# Altium schematic sheet mmb-2016-003_io.schdoc(mmb-2016-003_io)
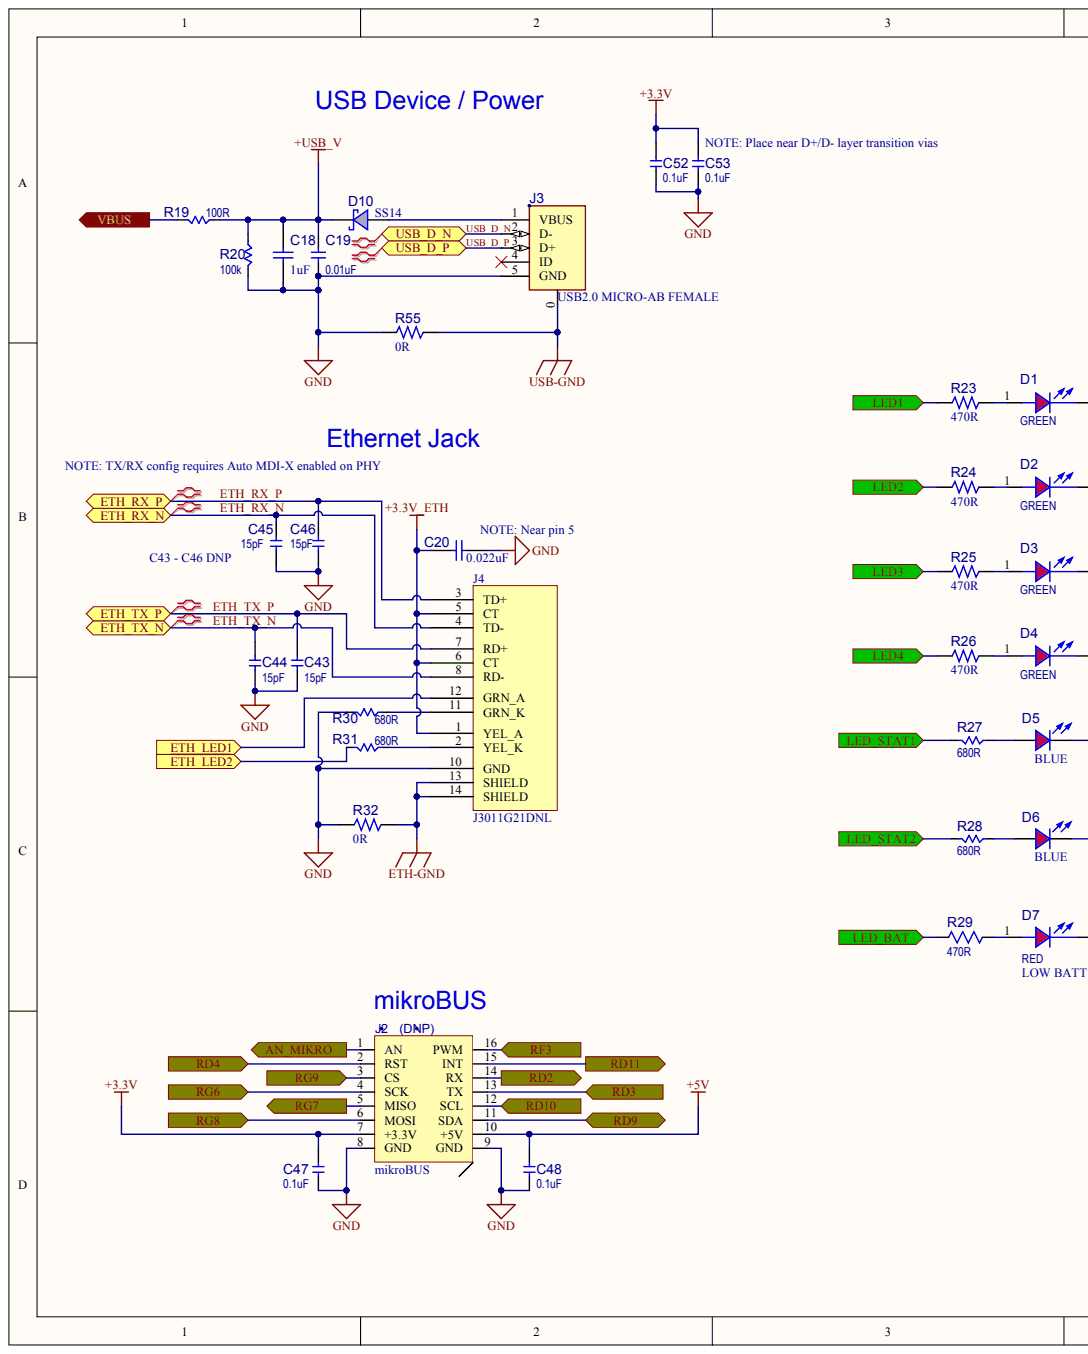
[diagram p0: mmb-2016-003_io.schdoc, left]
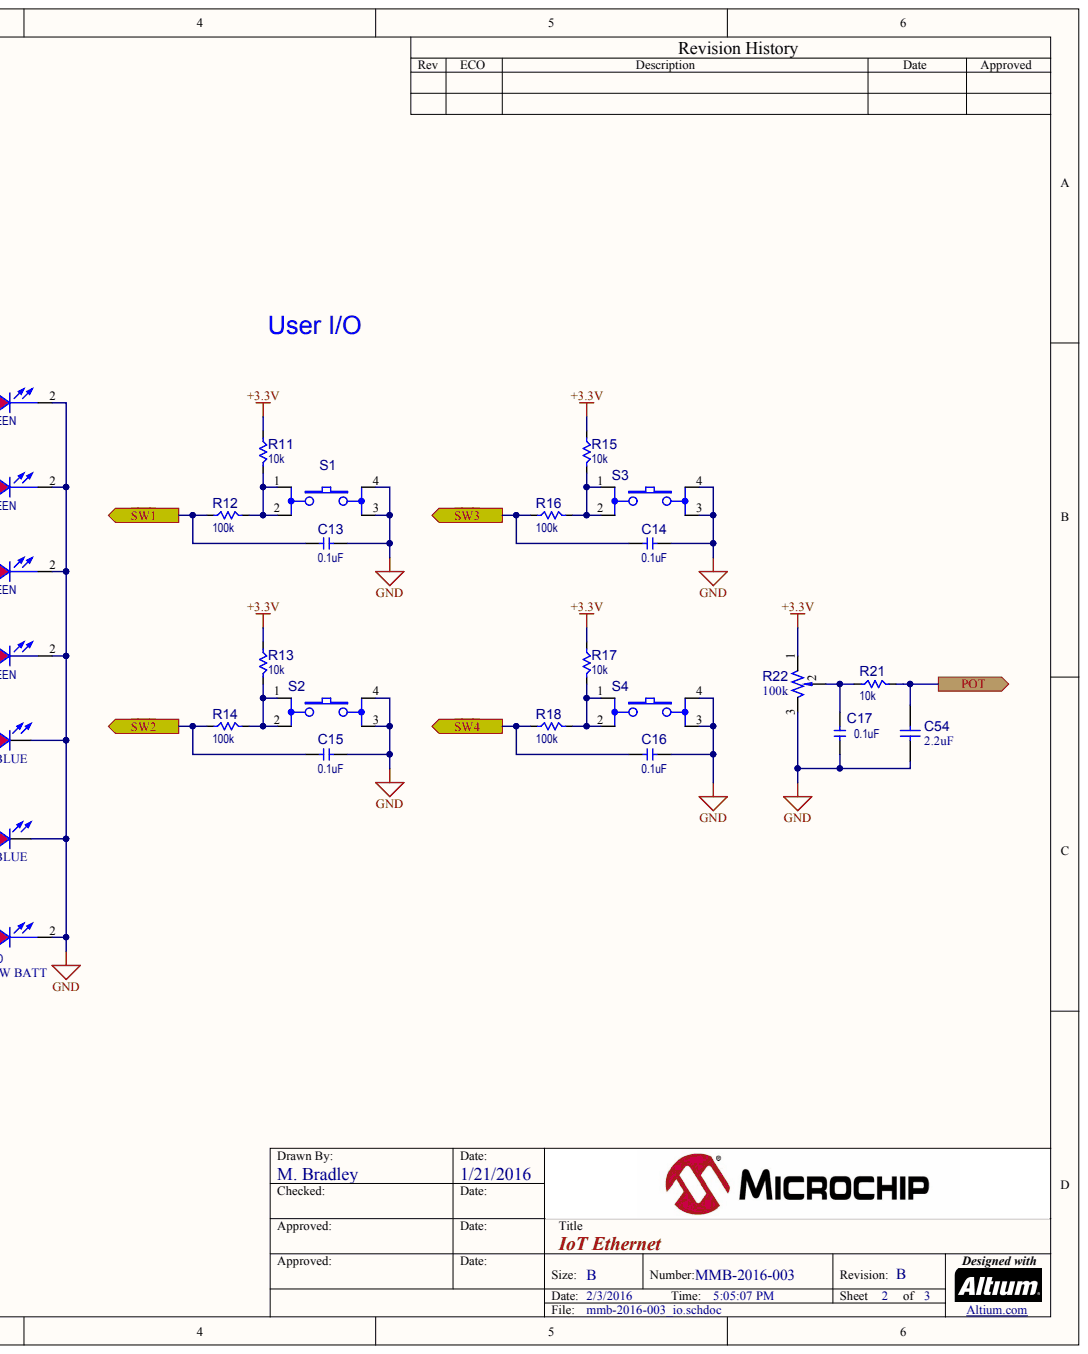
[diagram p1: mmb-2016-003_io.schdoc, right]

## Parts
C13  value 0.1uF  [CAP_C_0603]  (hidden comment: Capacitor NP)
C14  value 0.1uF  [CAP_C_0603]  (hidden comment: Capacitor NP)
C15  value 0.1uF  [CAP_C_0603]  (hidden comment: Capacitor NP)
C16  value 0.1uF  [CAP_C_0603]  (hidden comment: Capacitor NP)
C17  value 0.1uF  [CAP_C_0603]  (hidden comment: Capacitor NP)
C18  value 1uF  [CAP_C_0603]  (hidden comment: Capacitor NP)
C19  value 0.01uF  [CAP_C_0603]  (hidden comment: Capacitor NP)
C20  value 0.022uF  [CAP_C_0603]  (hidden comment: Capacitor NP)
C43  value 15pF  [CAP_C_0603]  (hidden comment: Capacitor NP)  (no BOM)
C44  value 15pF  [CAP_C_0603]  (hidden comment: Capacitor NP)  (no BOM)
C45  value 15pF  [CAP_C_0603]  (hidden comment: Capacitor NP)  (no BOM)
C46  value 15pF  [CAP_C_0603]  (hidden comment: Capacitor NP)  (no BOM)
C47  value 0.1uF  [CAP_C_0603]  (hidden comment: Capacitor NP)
C48  value 0.1uF  [CAP_C_0603]  (hidden comment: Capacitor NP)
C52  value 0.1uF  [CAP_C_0603]  (hidden comment: Capacitor NP)
C53  value 0.1uF  [CAP_C_0603]  (hidden comment: Capacitor NP)
C54  value 2.2uF  [CAP_C_0603]  (hidden comment: Capacitor NP)
D1  value GREEN  [LED_0805_Green]  (hidden comment: LED)
D2  value GREEN  [LED_0805_Green]  (hidden comment: LED)
D3  value GREEN  [LED_0805_Green]  (hidden comment: LED)
D4  value GREEN  [LED_0805_Green]  (hidden comment: LED)
D5  value BLUE  [LED_0805_BLUE]  (hidden comment: LED)
D6  value BLUE  [LED_0805_BLUE]  (hidden comment: LED)
D7  LOW BATT  value RED  [LED_0805_Red]
D10  value SS14  [DO-214AC_SMA]  (hidden comment: Diode Schottky)
J2  (DNP)  value mikroBUS  Package: mikroBUS  [MIKROBUS HOST CONN.]  (no BOM)
J3  value USB2.0 MICRO-AB FEMALE  [CON_USB_Micro-AB_SMD_RA_HIR_ZX62-AB-5PA(11)]  (hidden comment: CON USB2.0 Mini_Micro)
J4  value J3011G21DNL  Manufacturer Part Number 1: J3011G21DNL  [CONN_MAGJACK_SMT_J3011G21DNL]  (hidden comment: CON RJ-45 J3011G21DNL-2213)
R11  value 10k  [RES_C_0603]  (hidden comment: Resistor)
R12  value 100k  [RES_C_0603]  (hidden comment: Resistor)
R13  value 10k  [RES_C_0603]  (hidden comment: Resistor)
R14  value 100k  [RES_C_0603]  (hidden comment: Resistor)
R15  value 10k  [RES_C_0603]  (hidden comment: Resistor)
R16  value 100k  [RES_C_0603]  (hidden comment: Resistor)
R17  value 10k  [RES_C_0603]  (hidden comment: Resistor)
R18  value 100k  [RES_C_0603]  (hidden comment: Resistor)
R19  value 100R  [RES_C_0603]  (hidden comment: Resistor)
R20  value 100k  [RES_C_0603]  (hidden comment: Resistor)
R21  value 10k  [RES_C_0603]  (hidden comment: Resistor)
R22  value 100k  [RES_VAR_BOU_3352T_L8.89W8.89H3.81]  (hidden comment: Resistor Var)
R23  value 470R  [RES_C_0603]  (hidden comment: Resistor)
R24  value 470R  [RES_C_0603]  (hidden comment: Resistor)
R25  value 470R  [RES_C_0603]  (hidden comment: Resistor)
R26  value 470R  [RES_C_0603]  (hidden comment: Resistor)
R27  value 680R  [RES_C_0603]  (hidden comment: Resistor)
R28  value 680R  [RES_C_0603]  (hidden comment: Resistor)
R29  value 470R  [RES_C_0603]  (hidden comment: Resistor)
R30  value 680R  [RES_C_0603]  (hidden comment: Resistor)
R31  value 680R  [RES_C_0603]  (hidden comment: Resistor)
R32  value 0R  [RES_C_0805]  (hidden comment: Resistor)
R55  value 0R  [RES_C_0805]  (hidden comment: Resistor)
S1  Switch 4 Pin  [SW_SMD_6mmX6mm_TL3301]  (value not drawn)
S2  Switch 4 Pin  [SW_SMD_6mmX6mm_TL3301]  (value not drawn)
S3  Switch 4 Pin  [SW_SMD_6mmX6mm_TL3301]  (value not drawn)
S4  Switch 4 Pin  [SW_SMD_6mmX6mm_TL3301]  (value not drawn)

## Ports
AN_MIKRO, ETH_LED1, ETH_RX_N, ETH_RX_P, ETH_TX_N, ETH_TX_P, LED1, LED2, LED3, LED4, LED_BAT, LED_STAT1, LED_STAT2, POT, RD2, RD3, RD4, RD9, RD10, RD11, RF3, RG6, RG7, RG8, RG9, USB_D_N, USB_D_P, VBUS, ~{ETH_LED2}, ~{SW1}, ~{SW2}, ~{SW3}, ~{SW4}

## Nets
+3.3V: C47.1 C52.1 C53.1 J2.7(+3.3V) R11.2 R13.2 R15.2 R17.2 R22.1
+3.3V_ETH: C20.1 J4.1(YEL_A) J4.5(CT) J4.6(CT)
+5V: C48.1 J2.10(+5V)
+USB_V: C18.1 C19.2 D10.2 R19.2 R20.2
ETH-GND: J4.13(SHIELD) J4.14(SHIELD) R32.2
GND: C13.1 C14.1 C15.1 C16.1 C17.1 C18.2 C19.1 C20.2 C43.1 C44.1 C45.1 C46.1 C47.2 C48.2 C52.2 C53.2 C54.1 D1.2 D2.2 D3.2 D4.2 D5.2 D6.2 D7.2 J2.8(GND) J2.9(GND) J3.5(GND) J4.10(GND) R20.1 R22.3 R30.1 R32.1 R55.1 S1.3 S1.4 S2.3 S2.4 S3.3 S3.4 S4.3 S4.4
USB-GND: J3.0 R55.2
AN_MIKRO: J2.1(AN) ; port AN_MIKRO
~{ETH_LED2}: R31.1 ; port ~{ETH_LED2}
ETH_LED1: J4.12(GRN_A) ; port ETH_LED1
ETH_RX_N: C45.2 J4.4(TD-) ; port ETH_RX_N
ETH_RX_P: C46.2 J4.3(TD+) ; port ETH_RX_P
ETH_TX_N: C44.2 J4.8(RD-) ; port ETH_TX_N
ETH_TX_P: C43.2 J4.7(RD+) ; port ETH_TX_P
LED1: R23.1 ; port LED1
LED2: R24.1 ; port LED2
LED3: R25.1 ; port LED3
LED4: R26.1 ; port LED4
LED_BAT: R29.1 ; port LED_BAT
LED_STAT1: R27.1 ; port LED_STAT1
LED_STAT2: R28.1 ; port LED_STAT2
POT: C54.2 R21.1 ; port POT
RD2: J2.14(RX) ; port RD2
RD3: J2.13(TX) ; port RD3
RD4: J2.2(RST) ; port RD4
RD9: J2.11(SDA) ; port RD9
RD10: J2.12(SCL) ; port RD10
RD11: J2.15(INT) ; port RD11
RF3: J2.16(PWM) ; port RF3
RG6: J2.4(SCK) ; port RG6
RG7: J2.5(MISO) ; port RG7
RG8: J2.6(MOSI) ; port RG8
RG9: J2.3(CS) ; port RG9
~{SW1}: C13.2 R12.2 ; port ~{SW1}
~{SW2}: C15.2 R14.2 ; port ~{SW2}
~{SW3}: C14.2 R16.2 ; port ~{SW3}
~{SW4}: C16.2 R18.2 ; port ~{SW4}
USB_D_N: J3.2(D-) ; port USB_D_N
USB_D_P: J3.3(D+) ; port USB_D_P
VBUS: R19.1 ; port VBUS
NetC17_2: C17.2 R21.2 R22.2
NetD1_1: D1.1 R23.2
NetD2_1: D2.1 R24.2
NetD3_1: D3.1 R25.2
NetD4_1: D4.1 R26.2
NetD5_1: D5.1 R27.2
NetD6_1: D6.1 R28.2
NetD7_1: D7.1 R29.2
NetD10_1: D10.1 J3.1(VBUS)
NetJ4_2: J4.2(YEL_K) R31.2
NetJ4_11: J4.11(GRN_K) R30.2
NetR11_1: R11.1 R12.1 S1.1 S1.2
NetR13_1: R13.1 R14.1 S2.1 S2.2
NetR15_1: R15.1 R16.1 S3.1 S3.2
NetR17_1: R17.1 R18.1 S4.1 S4.2

Not connected: J3 4(ID)

## Library data of the parts
C13-C17 C47 C48 C52 C53 = CAP1166: Capacitor; Manufacturer 1: TDK; Manufacturer Part Number 1: C1608X7R1C104K; Description_: CAP CER 0.1uF 16V 10% X7R 0603; Owner: Bogdan P (M91398); Populated: Yes; Temperature Coeffcient: X7R; Tolerance: 10%; Type: Ceramic; Voltage: 16V; Category: Capacitor; Component Kind: Standard; ComponentLink1Description: Datasheet; ComponentLink1URL: https://chn-vm-apps.microchip.com/mchprepo/parts/Datasheets/general_B11.pdf; Database Table Name: Capacitor; Library Name: MCHP-CDB.DbLib; MCHP-ID: CAP1166; Operating Temperature: -55C to +125C; Package: 0603; Quantity Override: 1; Revision: 0; Status: Production; Supplier 1: Digi-Key; Supplier Part Number 1: 445-1317-1-ND
C18 = CAP0265: Capacitor; Manufacturer 1: AVX; Manufacturer Part Number 1: 0603YD105KAT2A; Description_: CAP CER 1uF 16V 10% X5R SMD 0603; Populated: Yes; Temperature Coeffcient: X5R; Tolerance: 10%; Type: Ceramic; Voltage: 16V; Category: Capacitor; Component Kind: Standard; ComponentLink1Description: Datasheet; ComponentLink1URL: https://chn-vm-apps.microchip.com/mchprepo/parts/Datasheets/smccp.pdf; Database Table Name: Capacitor; Library Name: MCHP-CDB-Offline.DbLib; Manufacturer 2: Murata; Manufacturer 3: Murata; Manufacturer 4: Panasonic; Manufacturer 5: Taiyo Yuden; Manufacturer 6: TDK; Manufacturer Part Number 2: GRM188R61C105KA93D; Manufacturer Part Number 3: GRM185R61C105KE44D; Manufacturer Part Number 4: ECJ-1VB1C105K; Manufacturer Part Number 5: EMK107BJ105KA-T; Manufacturer Part Number 6: C1608X5R1C105K; MCHP-ID: CAP0265; Operating Temperature: -55C to +85C; Package: 0603; Quantity Override: 1; Revision: 1; Status: Production; Supplier 1: Digi-Key; Supplier 2: Digi-Key; Supplier 3: Digi-Key; Supplier 4: Digi-Key; Supplier 5: Digi-Key; Supplier 6: Digi-Key; Supplier Part Number 1: 478-1249-1-ND; Supplier Part Number 2: 490-1536-1-ND; Supplier Part Number 3: 490-3894-1-ND; Supplier Part Number 4: PCC2224CT-ND; Supplier Part Number 5: 587-1251-1-ND; Supplier Part Number 6: 445-1416-1-ND
C19 = CAP0451: Capacitor; Manufacturer 1: Panasonic; Manufacturer Part Number 1: ECJ-1VB1C103K; Description_: CAP CER 0.01uF 16V 10% X7R SMD 0603; Populated: Yes; Temperature Coeffcient: X7R; Tolerance: 10%; Type: Ceramic; Voltage: 16V; Category: Capacitor; Component Kind: Standard; ComponentLink1Description: Datasheet; ComponentLink1URL: https://chn-vm-apps.microchip.com/mchprepo/parts/Datasheets/L0005E.pdf; Database Table Name: Capacitor; Library Name: MCHP-CDB.DbLib; Manufacturer 2: Murata; Manufacturer 3: Johanson Dielectrics; Manufacturer Part Number 2: GRM188R71C103KA01D; Manufacturer Part Number 3: 160R14W103KV4T; MCHP-ID: CAP0451; Operating Temperature: -55C to +125C; Package: 0603; Quantity Override: 1; Revision: 1; Status: Production; Supplier 1: Digi-Key; Supplier 2: Digi-Key; Supplier 3: Digi-Key; Supplier Part Number 1: PCC1750CT-ND; Supplier Part Number 2: 490-1525-1-ND; Supplier Part Number 3: 709-1152-2-ND
C20 = CAP1058: Capacitor; Manufacturer 1: TDK; Manufacturer Part Number 1: C1608X7R1H223K; Description_: CAP CER 0.022uF 50V 10% X7R SMD 0603; Owner: Petnarong Chaijaroong; Populated: YES; Temperature Coeffcient: X7R; Tolerance: 10%; Type: Ceramic; Voltage: 50V; Category: Capacitor; Component Kind: Standard; ComponentLink1Description: Datasheet; ComponentLink1URL: https://chn-vm-apps.microchip.com/mchprepo/parts/Datasheets/general_B11.pdf; Database Table Name: Capacitor; Library Name: MCHP-CDB-Offline.DbLib; MCHP-ID: CAP1058; Operating Temperature: -55C to +125C; Package: 0603; Quantity Override: 1; Revision: 0; Status: Production; Supplier 1: Digi-Key; Supplier Part Number 1: 445-1312-1-ND
C43-C46 = CAP0121: Capacitor; Manufacturer 1: Panasonic; Manufacturer Part Number 1: ECJ-1VC1H150J; Description_: CAP CER 15pF 50V 5% NP0 SMD 0603; Populated: Yes; Temperature Coeffcient: NP0; Tolerance: 5%; Type: Ceramic; Voltage: 50V; Category: Capacitor; ComponentLink1Description: Datasheet; ComponentLink1URL: https://chn-vm-apps.microchip.com/mchprepo/parts/Datasheets/MLCC_ordering_code.pdf; Database Table Name: Capacitor; Library Name: MCHP-CDB.DbLib; Manufacturer 2: TDK; Manufacturer 3: Yageo; Manufacturer Part Number 2: C1608C0G1H150J; Manufacturer Part Number 3: CC0603JRNP09BN150; MCHP-ID: CAP0121; Operating Temperature: -55C to +125C; Package: 0603; Quantity Override: 1; Revision: 1; Status: Production; Supplier 1: Digi-Key; Supplier 2: Digi-Key; Supplier 3: Digi-Key; Supplier Part Number 1: PCC150ACVTR-ND; Supplier Part Number 2: 445-1271-1-ND; Supplier Part Number 3: 311-1060-1-ND
C54 = CAP0559: Capacitor; Manufacturer 1: Murata; Manufacturer Part Number 1: GRM188R71A225KE15D; Description_: CAP CER 2.2uF 10V 10% X7R SMD 0603; Dev Tools Status: Production; Populated: Yes; Temperature Coeffcient: X7R; Tolerance: 10%; Type: Ceramic; Voltage: 10V; Category: Capacitor; Component Kind: Standard; ComponentLink1Description: Datasheet; ComponentLink1URL: https://chn-vm-apps.microchip.com/mchprepo/parts/Datasheets/L0005E.pdf; Database Table Name: Capacitor; Library Name: MCHP-CDB.DbLib; MCHP-ID: CAP0559; Operating Temperature: -55C to +125C; Package: 0603; Quantity Override: 1; Revision: 1; Status: MCL Design; Supplier 1: Digi-Key; Supplier Part Number 1: 490-4520-1-ND
D1-D4 = LED1022: Manufacturer 1: Lumex Opto/Components Inc; Manufacturer Part Number 1: SML-LXT0805GW-TR; Database Table Name: Diode; Description_: DIO LED GREEN 2V 30mA 0805; Forward Current: 30mA; Owner: Stephen Humberd; Populated: YES; Power: 50mW; Reverse Current: 100uA; Reverse Voltage: 5V; Type: LED Single; Category: Diode; Component Kind: Standard; ComponentLink1Description: Datasheet; ComponentLink1URL: https://chn-vm-apps.microchip.com/mchprepo/parts/Datasheets/SML-LXT0805GW-TR.pdf; Description: LED; Library Name: MCHP-CDB.DbLib; MCHP-ID: LED1022; Operating Temperature: -25C to +80C; Package: 0805; Quantity Override: 1; Revision: 0; Status: Production; Supplier 1: Digi-Key; Supplier Part Number 1: 67-1553-1-ND
D5 D6 = DIODE1080: Manufacturer 1: Kingbright Company LLC; Manufacturer Part Number 1: APT2012QBC/D; Database Table Name: Diode; Description_: DIO LED BLUE 3.3V 20mA 100mcd Clear SMD 0805; Forward Current: 20mA; Owner: Tad Ahlvers; Populated: YES; Power: 120mW; Reverse Current: 50uA; Reverse Voltage: 5V; Type: LED Single; Category: Diode; Component Kind: Standard; ComponentLink1Description: Datasheet; ComponentLink1URL: https://chn-vm-apps.microchip.com/mchprepo/parts/Datasheets/APT2012QBC-D.pdf; Description: LED; Library Name: MCHP-CDB-Offline.DbLib; MCHP-ID: DIODE1080; Operating Temperature: -40C to +85C; Package: 0805; Quantity Override: 1; Revision: A; Status: Design; Supplier 1: Digi-Key; Supplier Part Number 1: 754-1437-1-ND
D7 = LED1020: LED; Manufacturer 1: Lumex; Manufacturer Part Number 1: SML-LXT0805IW-TR; Database Table Name: Diode; Description_: DIO LED RED 2V 30mA 0805; Forward Current: 30mA; Owner: Stephen Humberd; Populated: YES; Power: 50mW; Reverse Current: 100uA; Reverse Voltage: 5V; Type: LED Single; Category: Diode; Component Kind: Standard; ComponentLink1Description: Datasheet; ComponentLink1URL: https://chn-vm-apps.microchip.com/mchprepo/parts/Datasheets/SML-LXT0805IW-TR.pdf; Library Name: MCHP-CDB.DbLib; MCHP-ID: LED1020; Operating Temperature: -25C to +80C; Package: 0805; Quantity Override: 1; Revision: A; Status: Design; Supplier 1: Digi-Key; Supplier Part Number 1: 67-1552-1-ND
D10 = DIODE0150: Manufacturer 1: Diodes Incorporated; Manufacturer Part Number 1: B140-13; Description_: DIO SCTKY SS14 500mV 1A 40V DO-214AC_SMA; Forward Current: 1A; Populated: Yes; Reverse Current: 200uA; Reverse Voltage: 40V; Type: Schottky Single; Category: Diode; Component Kind: Standard; ComponentLink1Description: Datasheet; ComponentLink1URL: https://chn-vm-apps.microchip.com/mchprepo/parts/Datasheets/ss12.pdf; Database Table Name: Diode; Library Name: MCHP-CDB-Offline.DbLib; Manufacturer 2: Diodes Incorporated; Manufacturer 3: Vishay; Manufacturer Part Number 2: B140-13-F; Manufacturer Part Number 3: SS14-E3/61T; MCHP-ID: DIODE0150; Operating Temperature: -65C to +125C; Package: DO-214AC_SMA; Quantity Override: 1; Revision: 2; Status: Production; Supplier 1: Digi-Key; Supplier 2: Diodes Incorporated; Supplier 3: Digi-Key; Supplier Part Number 1: B140DICT-ND; Supplier Part Number 2: B140-13-F; Supplier Part Number 3: SS14-E3/61TGICT-ND
J2 = SKT1042: Manufacturer 1: Sullins Connector Solutions; Manufacturer Part Number 1: PPTC081LFBN-RC; Database Table Name: Socket; Description_: mikroBUS HOST; Owner: Stephen Humberd; Populated: YES; Category: Socket; ComponentLink1Description: Datasheet; ComponentLink1URL: https://chn-vm-apps.microchip.com/mchprepo/parts/Datasheets/mikrobus_specification.pdf; Library Name: MCHP-CDB-Offline.DbLib; MCHP-ID: SKT1042; Quantity Override: 2; Revision: A; Status: Design; Supplier 1: Sullins Connector Solutions; Supplier Part Number 1: PPTC081LFBN-RC; Type: PIN
J3 = CON0436: Connector USB Mini; Manufacturer 1: Hirose; Manufacturer Part Number 1: ZX62-AB-5PA(11); Description_: CON USB2.0 MICRO-AB FEMALE SMD R/A; Dev Tools Status: Production; Populated: YES; Category: Connector; Component Kind: Standard; ComponentLink1Description: Datasheet; ComponentLink1URL: https://chn-vm-apps.microchip.com/mchprepo/parts/Datasheets/e24200011.pdf; Database Table Name: Connector; Library Name: MCHP-CDB.DbLib; MCHP-ID: CON0436; Quantity Override: 1; Revision: 1; Status: MCL Design; Supplier 1: Digi-Key; Supplier Part Number 1: H11635CT-ND; Type: USB
J4 = CON1378: Manufacturer 1: Pulse Electronics; Database Table Name: Connector; Description_: CON MODULAR RJ45 ETHERNET J3011G21DNL; Dev Tools Status: Production; Owner: Randolph Sobrepena; Populated: YES; Category: Connector; Component Kind: Standard; ComponentLink1Description: Datasheet; ComponentLink1URL: https://chn-vm-apps.microchip.com/mchprepo/parts/Datasheets/J409; Library Name: MCHP-CDB.DbLib; MCHP-ID: CON1378; Quantity Override: 1; Revision: 1; Status: MCL Design; Supplier 1: Digi-Key; Supplier Part Number 1: 553-1491-ND; Type: Modular
R11 R13 R15 R17 R21 = RES0191: Manufacturer 1: Panasonic; Manufacturer Part Number 1: ERJ-3GEYJ103V; Description_: RES TKF 10k 5% 1/10W SMD 0603; Populated: Yes; Power: 1/10W; Temperature Coeffcient: 200ppm/C; Tolerance: 5%; Voltage: 75V; Category: Resistor; Component Kind: Standard; ComponentLink1Description: Datasheet; ComponentLink1URL: https://chn-vm-apps.microchip.com/mchprepo/parts/Datasheets/AOA0000CE1.pdf; Composition: TKF; Database Table Name: Resistor; Description: Resistor; Library Name: MCHP-CDB.DbLib; Manufacturer 2: NIC Components; Manufacturer 3: Yageo; Manufacturer Part Number 2: NRC06J103TRF; Manufacturer Part Number 3: RC0603JR-0710KL; MCHP-ID: RES0191; Operating Temperature: -55C to +155C; Package: 0603; Quantity Override: 1; Revision: 2; Status: Production; Supplier 1: Digi-Key; Supplier 2: Digi-Key; Supplier 3: NIC Components; Supplier 4: Digi-Key; Supplier Part Number 1: P10KGCT-ND; Supplier Part Number 2: P10KGTR-ND; Supplier Part Number 3: NRC06J103TRF; Supplier Part Number 4: 311-10KGRTR-ND; Type: RES SMD
R12 R14 R16 R18 R20 = RSMT0026: Manufacturer 1: Panasonic; Manufacturer Part Number 1: ERJ-3GEYJ104V; Description_: RES TKF 100k 5% 1/10W SMD 0603; Populated: Yes; Power: 1/10W; Temperature Coeffcient: 200ppm/C; Tolerance: 5%; Voltage: 75V; Category: Resistor; Component Kind: Standard; ComponentLink1Description: Datasheet; ComponentLink1URL: https://chn-vm-apps.microchip.com/mchprepo/parts/Datasheets/AOA0000CE1.pdf; Composition: TKF; Database Table Name: Resistor; Description: Resistor; Library Name: MCHP-CDB.DbLib; Manufacturer 2: KOA Speer; Manufacturer 3: Panasonic; Manufacturer 4: ROHM; Manufacturer 5: Stackpole Electronics Inc; Manufacturer Part Number 2: RK73B1JTTD104J; Manufacturer Part Number 3: ERJ-3GSYJ104V; Manufacturer Part Number 4: MCR03EZPJ104; Manufacturer Part Number 5: RMCF 1/16 100K 5% R; MCHP-ID: RSMT0026; Operating Temperature: -55C to +155C; Package: 0603; Quantity Override: 1; Revision: 2; Status: Production; Supplier 1: Digi-Key; Supplier 2: KOA Speer; Supplier 3: Digi-Key; Supplier 4: Stackpole Electronics Inc; Supplier Part Number 1: P100KGTR-ND; Supplier Part Number 2: RK73B1JTTD104J; Supplier Part Number 3: RHM100KGTR-ND; Supplier Part Number 4: RMCF 1/16 100K 5% R; Type: RES SMD
R19 = RES0193: Manufacturer 1: ROHM; Manufacturer Part Number 1: MCR03EZPJ101; Description_: RES TKF 100R 5% 1/10W SMD 0603; Populated: Yes; Power: 1/10W; Temperature Coeffcient: 100ppm/C; Tolerance: 5%; Voltage: 50V; Category: Resistor; Component Kind: Standard; ComponentLink1Description: Datasheet; ComponentLink1URL: https://chn-vm-apps.microchip.com/mchprepo/parts/Datasheets/mcr_a.pdf; Composition: TKF; Database Table Name: Resistor; Description: Resistor; Library Name: MCHP-CDB.DbLib; MCHP-ID: RES0193; Operating Temperature: -55C to +155C; Package: 0603; Quantity Override: 1; Revision: 1; Status: Production; Supplier 1: Digi-Key; Supplier Part Number 1: RHM100GCT-ND; Type: RES SMD
R22 = RPOT0037: Manufacturer 1: Bourns; Manufacturer Part Number 1: 3352T-1-104LF; Circuit Type: Single Turn; Description_: RES TRIMMER Cermet 100k 20% 500mW TH 91A; Populated: YES; Power: 500mW; Temperature Coeffcient: 100ppm/C; Tolerance: 20%; Category: Resistor; Component Kind: Standard; ComponentLink1Description: Datasheet; ComponentLink1URL: https://chn-vm-apps.microchip.com/mchprepo/parts/Datasheets/91.pdf; Composition: Cermet; Database Table Name: Resistor; Description: Resistor Var; Library Name: MCHP-CDB.DbLib; Manufacturer 2: BI Technologies; Manufacturer Part Number 2: 91AR100KLF; MCHP-ID: RPOT0037; Operating Temperature: -55C to +125C; Package: 91A; Quantity Override: 1; Revision: 1; Status: Design; Supplier 1: Digi-Key; Supplier 2: Mouser; Supplier Part Number 1: 3352T-104LF-ND; Supplier Part Number 2: 858-91AR100KLF; Type: Trimmer
R23-R26 = RSMT0098: Manufacturer 1: Panasonic; Manufacturer Part Number 1: ERJ-3GEYJ471V; Description_: RES TKF 470R 5% 1/10W SMD 0603; Dev Tools Status: Production; Populated: Yes; Power: 1/10W; Temperature Coeffcient: 200ppm/C; Tolerance: 5%; Category: Resistor; Component Kind: Standard; ComponentLink1Description: Datasheet; ComponentLink1URL: https://chn-vm-apps.microchip.com/mchprepo/parts/Datasheets/nrc.pdf; Composition: TKF; Database Table Name: Resistor; Description: Resistor; Library Name: MCHP-CDB.DbLib; Manufacturer 2: Stackpole Electronics Inc; Manufacturer 3: NIC Components; Manufacturer Part Number 2: RMCF0603JT470R; Manufacturer Part Number 3: NRC06J471TRF; MCHP-ID: RSMT0098; Operating Temperature: -55C to +155C; Package: 0603; Quantity Override: 1; Revision: 1; Status: MCL Design; Supplier 1: Digi-Key; Supplier 2: Digi-Key; Supplier 3: NIC Components; Supplier Part Number 1: P470GTR-ND; Supplier Part Number 2: RMCF0603JT470RCT-ND; Supplier Part Number 3: NRC06J471TRF; Type: RES SMD
R27 R28 R30 R31 = RSMT0122: Manufacturer 1: Panasonic; Manufacturer Part Number 1: ERJ-3GSYJ681V; Description_: RES TKF 680R 5% 1/10W SMD 0603; Populated: Yes; Power: 1/10W; Temperature Coeffcient: 200ppm/C; Tolerance: 5%; Voltage: 75V; Category: Resistor; Component Kind: Standard; ComponentLink1Description: Datasheet; ComponentLink1URL: https://chn-vm-apps.microchip.com/mchprepo/parts/Datasheets/AOA0000CE1.pdf; Composition: TKF; Database Table Name: Resistor; Description: Resistor; Library Name: MCHP-CDB.DbLib; Manufacturer 2: Panasonic; Manufacturer Part Number 2: ERJ-3GEYJ681V; MCHP-ID: RSMT0122; Operating Temperature: -55C to +155C; Package: 0603; Quantity Override: 1; Revision: 1; Status: Production; Supplier 1: Digi-Key; Supplier 2: Digi-Key; Supplier Part Number 1: P680GTR-ND; Supplier Part Number 2: P680GCT-ND; Type: RES SMD
R29 = RSMT0098: Manufacturer 1: NIC Components; Manufacturer Part Number 1: NRC06J471TRF; Description_: RES TKF 470R 5% 1/10W SMD 0603; Populated: Yes; Power: 1/10W; Temperature Coeffcient: 200ppm/C; Tolerance: 5%; Category: Resistor; Component Kind: Standard; ComponentLink1Description: Datasheet; ComponentLink1URL: https://chn-vm-apps.microchip.com/mchprepo/parts/Datasheets/nrc.pdf; Composition: TKF; Database Table Name: Resistor; Description: Resistor; Library Name: MCHP-CDB.DbLib; Manufacturer 2: Panasonic; Manufacturer 3: Stackpole Electronics Inc; Manufacturer Part Number 2: ERJ-3GEYJ471V; Manufacturer Part Number 3: RMCF0603JT470R; MCHP-ID: RSMT0098; Operating Temperature: -55C to +155C; Package: 0603; Quantity Override: 1; Revision: 1; Status: Production; Supplier 1: NIC Components; Supplier 2: Digi-Key; Supplier 3: Digi-Key; Supplier Part Number 1: NRC06J471TRF; Supplier Part Number 2: P470GTR-ND; Supplier Part Number 3: RMCF0603JT470RCT-ND; Type: RES SMD
R32 R55 = RES1218: Manufacturer 1: Panasonic; Manufacturer Part Number 1: ERJ-6GEY0R00V; Current: 2; Description_: RES TKF 0R 1/8W SMD 0805; Dev Tools Status: Production; Owner: Adrian M (M91404); Populated: Yes; Power: 1/8W; Temperature Coeffcient: -100/+600ppm/C; Voltage: 150V; Category: Resistor; Component Kind: Standard; ComponentLink1Description: Datasheet; ComponentLink1URL: https://chn-vm-apps.microchip.com/mchprepo/parts/Datasheets/AOA0000CE1.pdf; Composition: TKF; Database Table Name: Resistor; Description: Resistor; Library Name: MCHP-CDB.DbLib; MCHP-ID: RES1218; Operating Temperature: -55C to +155C; Package: 0805; Quantity Override: 1; Revision: 1; Status: MCL Design; Supplier 1: Digi-Key; Supplier Part Number 1: P0.0ACT-ND; Type: RES SMD
S1-S4 = SWITCH0095: Switch; Manufacturer 1: E-Switch; Manufacturer Part Number 1: TL3301AF160QG; Current: 50mA; Description_: SWITCH SPST 12V 50mA TL3301AF160QG SMD; Populated: Yes; Value: SPST; Voltage: 12V; Category: Switch; Component Kind: Standard; ComponentLink1Description: Datasheet; ComponentLink1URL: https://chn-vm-apps.microchip.com/mchprepo/parts/Datasheets/TL3301.pdf; Database Table Name: Switch; Library Name: MCHP-CDB.DbLib; MCHP-ID: SWITCH0095; Operating Temperature: -20C to +70C; Quantity Override: 1; Revision: 1; Status: Production; Supplier 1: Digi-Key; Supplier Part Number 1: EG2526CT-ND
